# Altium schematic sheet Gyrophare-CarteDeCommande.SchDoc
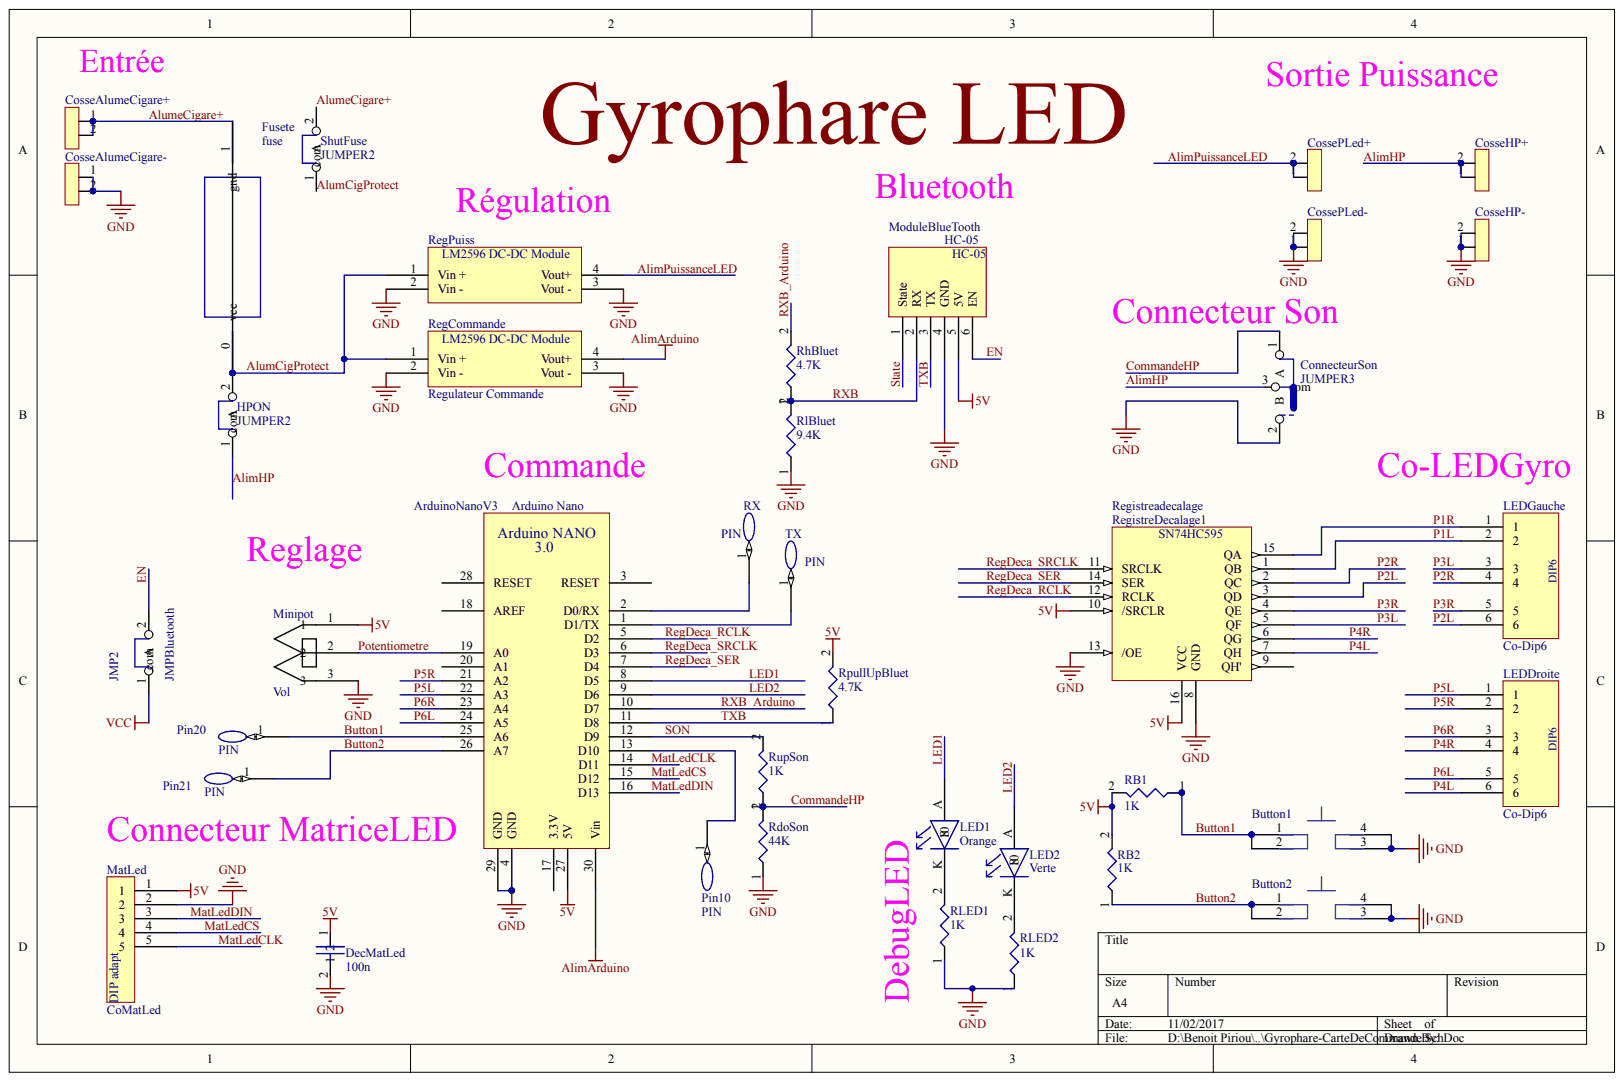
[diagram p0: Gyrophare-CarteDeCommande.SchDoc]

## Parts
ArduinoNanoV3  Arduino Nano
Button1  SwitchButton  (value not drawn)
Button2  SwitchButton  (value not drawn)
ConnecteurSon  JUMPER3
CosseAlumeCigare+  CosseAlumeCigare+
CosseAlumeCigare-  CosseAlumeCigare+
CosseHP+  comment  (value not drawn)
CosseHP-  comment  (value not drawn)
CossePLed+  comment  (value not drawn)
CossePLed-  comment  (value not drawn)
DecMatLed  value 100n  (hidden comment: CAP)
Fusete  fuse
HPON  JUMPER2
JMPBluetooth  JMP2
LED1  Orange
LED2  Verte
LEDDroite  Co-Dip6
LEDGauche  Co-Dip6
MatLed  CoMatLed
Minipot  Vol
ModuleBlueTooth  HC-05
Pin10  PIN
Pin20  PIN
Pin21  PIN
RB1  value 1K  (hidden comment: RES)
RB2  value 1K  (hidden comment: RES)
RdoSon  value 44K  (hidden comment: RES)
RegCommande  Regulateur Commande
RegistreDecalage1  Registreadecalage
RegPuiss  Regulateur Puissant  (value not drawn)
RhBluet  value 4.7K  (hidden comment: RES)
RlBluet  value 9.4K  (hidden comment: RES)
RLED1  value 1K  (hidden comment: RES)
RLED2  value 1K  (hidden comment: RES)
RpullUpBluet  value 4.7K  (hidden comment: RES)
RupSon  value 1K  (hidden comment: RES)
RX  PIN
ShutFuse  JUMPER2
TX  PIN

## Nets
5V: ArduinoNanoV3.27(5V) DecMatLed.1 MatLed.1 Minipot.1 ModuleBlueTooth.5(5V) RB1.2 RB2.2 RegistreDecalage1.10(/SRCLR) RegistreDecalage1.16(VCC) RpullUpBluet.2
ALIMARDUINO: ArduinoNanoV3.30(Vin) RegCommande.4(Vout+)
GND: ArduinoNanoV3.4(GND) ArduinoNanoV3.29(GND) Button1.3 Button1.4 Button2.3 Button2.4 ConnecteurSon.2 CosseAlumeCigare-.1 CosseAlumeCigare-.2 CosseHP-.1 CosseHP-.2 CossePLed-.1 CossePLed-.2 DecMatLed.2 MatLed.2 Minipot.3 ModuleBlueTooth.4(GND) RdoSon.1 RegCommande.2(Vin_-) RegCommande.3(Vout_-) RegistreDecalage1.8(GND) RegistreDecalage1.13(/OE) RegPuiss.2(Vin_-) RegPuiss.3(Vout_-) RlBluet.1 RLED1.1 RLED2.1
VCC: JMPBluetooth.1
ALIMHP: ConnecteurSon.3 CosseHP+.1 CosseHP+.2 HPON.1
ALIMPUISSANCELED: CossePLed+.1 CossePLed+.2 RegPuiss.4(Vout+)
ALUMCIGPROTECT: Fusete.0 HPON.2 RegCommande.1(Vin_+) RegPuiss.1(Vin_+) ShutFuse.1
ALUMECIGARE+: CosseAlumeCigare+.1 CosseAlumeCigare+.2 Fusete.1 ShutFuse.2
BUTTON1: ArduinoNanoV3.25(A6) Button1.1 Button1.2 Pin20.1 RB1.1
BUTTON2: ArduinoNanoV3.26(A7) Button2.1 Button2.2 Pin21.1 RB2.1
COMMANDEHP: ConnecteurSon.1 RdoSon.2 RupSon.1
EN: JMPBluetooth.2 ModuleBlueTooth.6(EN)
LED1: ArduinoNanoV3.8(D5) LED1.A
LED2: ArduinoNanoV3.9(D6) LED2.A
MATLEDCLK: ArduinoNanoV3.14(D11) MatLed.5
MATLEDCS: ArduinoNanoV3.15(D12) MatLed.4
MATLEDDIN: ArduinoNanoV3.16(D13) MatLed.3
P1L: LEDGauche.2 RegistreDecalage1.1(QB)
P1R: LEDGauche.1 RegistreDecalage1.15(QA)
P2L: LEDGauche.6 RegistreDecalage1.3(QD)
P2R: LEDGauche.4 RegistreDecalage1.2(QC)
P3L: LEDGauche.3 RegistreDecalage1.5(QF)
P3R: LEDGauche.5 RegistreDecalage1.4(QE)
P4L: LEDDroite.6 RegistreDecalage1.7(QH)
P4R: LEDDroite.4 RegistreDecalage1.6(QG)
P5L: ArduinoNanoV3.22(A3) LEDDroite.1
P5R: ArduinoNanoV3.21(A2) LEDDroite.2
P6L: ArduinoNanoV3.24(A5) LEDDroite.5
P6R: ArduinoNanoV3.23(A4) LEDDroite.3
POTENTIOMETRE: ArduinoNanoV3.19(A0) Minipot.2
REGDECA_RCLK: ArduinoNanoV3.5(D2) RegistreDecalage1.12(RCLK)
REGDECA_SER: ArduinoNanoV3.7(D4) RegistreDecalage1.14(SER)
REGDECA_SRCLK: ArduinoNanoV3.6(D3) RegistreDecalage1.11(SRCLK)
RXB: ModuleBlueTooth.2(RX) RhBluet.1 RlBluet.2
RXB_ARDUINO: ArduinoNanoV3.10(D7) RhBluet.2
SON: ArduinoNanoV3.12(D9) RupSon.2
STATE: ModuleBlueTooth.1(State)
TXB: ArduinoNanoV3.11(D8) ModuleBlueTooth.3(TX) RpullUpBluet.1
NetArduinoNanoV3_1: ArduinoNanoV3.1(D1/TX) TX.1
NetArduinoNanoV3_2: ArduinoNanoV3.2(D0/RX) RX.1
NetArduinoNanoV3_13: ArduinoNanoV3.13(D10) Pin10.1
NetLED1_K: LED1.K RLED1.2
NetLED2_K: LED2.K RLED2.2

Not connected: ArduinoNanoV3 3(RESET) 17(3.3V) 18(AREF) 20(A1) 28(RESET); RegistreDecalage1 9(QH')
